# Altium schematic sheet HV Interlock Circuit.SchDoc
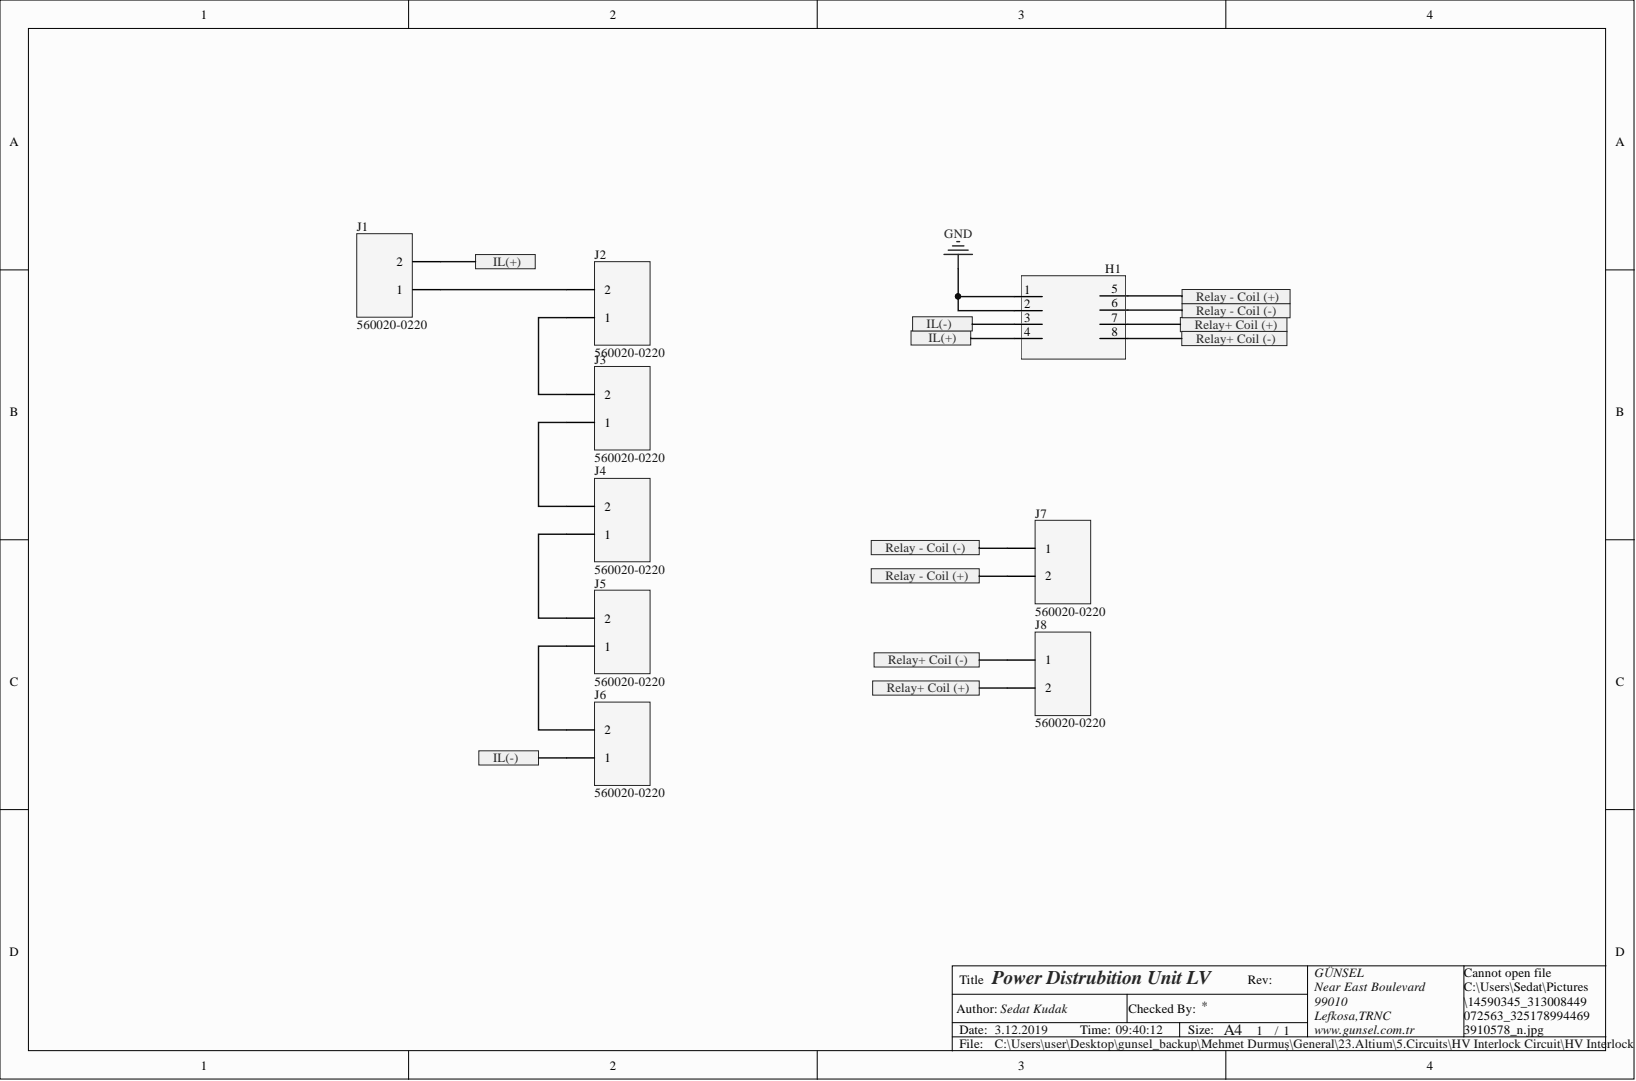
[diagram p0: HV Interlock Circuit.SchDoc]

## Parts
H1  TE-1379684-1  [TE-1379684-1]  (value not drawn)
J1  560020-0220  [560020-0220]
J2  560020-0220  [560020-0220]
J3  560020-0220  [560020-0220]
J4  560020-0220  [560020-0220]
J5  560020-0220  [560020-0220]
J6  560020-0220  [560020-0220]
J7  560020-0220  [560020-0220]
J8  560020-0220  [560020-0220]

## Ports
IL(+), IL(-), Relay - Coil (+), Relay - Coil (-), Relay+ Coil (+), Relay+ Coil (-)

## Nets
GND: H1.1 H1.2
NetH1_3: H1.3 J6.1
NetH1_4: H1.4 J1.2
NetH1_5: H1.5 J7.2
NetH1_6: H1.6 J7.1
NetH1_7: H1.7 J8.2
NetH1_8: H1.8 J8.1
NetJ1_1: J1.1 J2.2
NetJ2_1: J2.1 J3.2
NetJ3_1: J3.1 J4.2
NetJ4_1: J4.1 J5.2
NetJ5_1: J5.1 J6.2

## Library data of the parts
J1-J8 = 560020-0220: CONN HEADER SMD 2POS 2MM; Manufacturer: Molex; Connector Type: Male Pin; Gender: Male; Glow Wire Compliant: Non-compliant; Number of Circuits: 2; Pitch: 0.079inch; Color: Natural; Component Kind: Standard; Contact Material: Copper Alloy; Contact Plating: Tin; Fastening Type: Latch; Library Name: Integrated_Library1.IntLib; Manufacturer Part Number: 560020-0220; Mount: Surface Mount; Number of Contacts: 2; Number of Positions: 2; Number of Rows: 1; Orientation: Vertical; Packaging: Tape and Reel; Pricing 1: 1=0.78, 10=0.685, 100=0.5912 (USD); REACH SVHC: Unknown; Stock 1: 20760; Supplier 1: Digi-Key; Supplier Part Number 1: WM10862DKR-ND; Termination: Solder
H1 = TE-1379684-1: Component Kind: Standard; ComponentLink1Description: TE-HEADER ASSY,8w .025 SERIES, R.; ComponentLink1URL: https://www.te.com/usa-en/product-1379684-1.html; ComponentLink2Description: Mouser-Automotive Connectors HEADER ASSY 8w .025 SERIES R. ANGLE; ComponentLink2URL: https://eu.mouser.com/ProductDetail/TE-Connectivity/1379684-1?qs=GQ3BsEl46pnfvp5%2F0fNBmw==; Library Name: Thermal Management System Altium Library_v01.IntLib
